# Altium schematic sheet LCL_FIlter.SchDoc
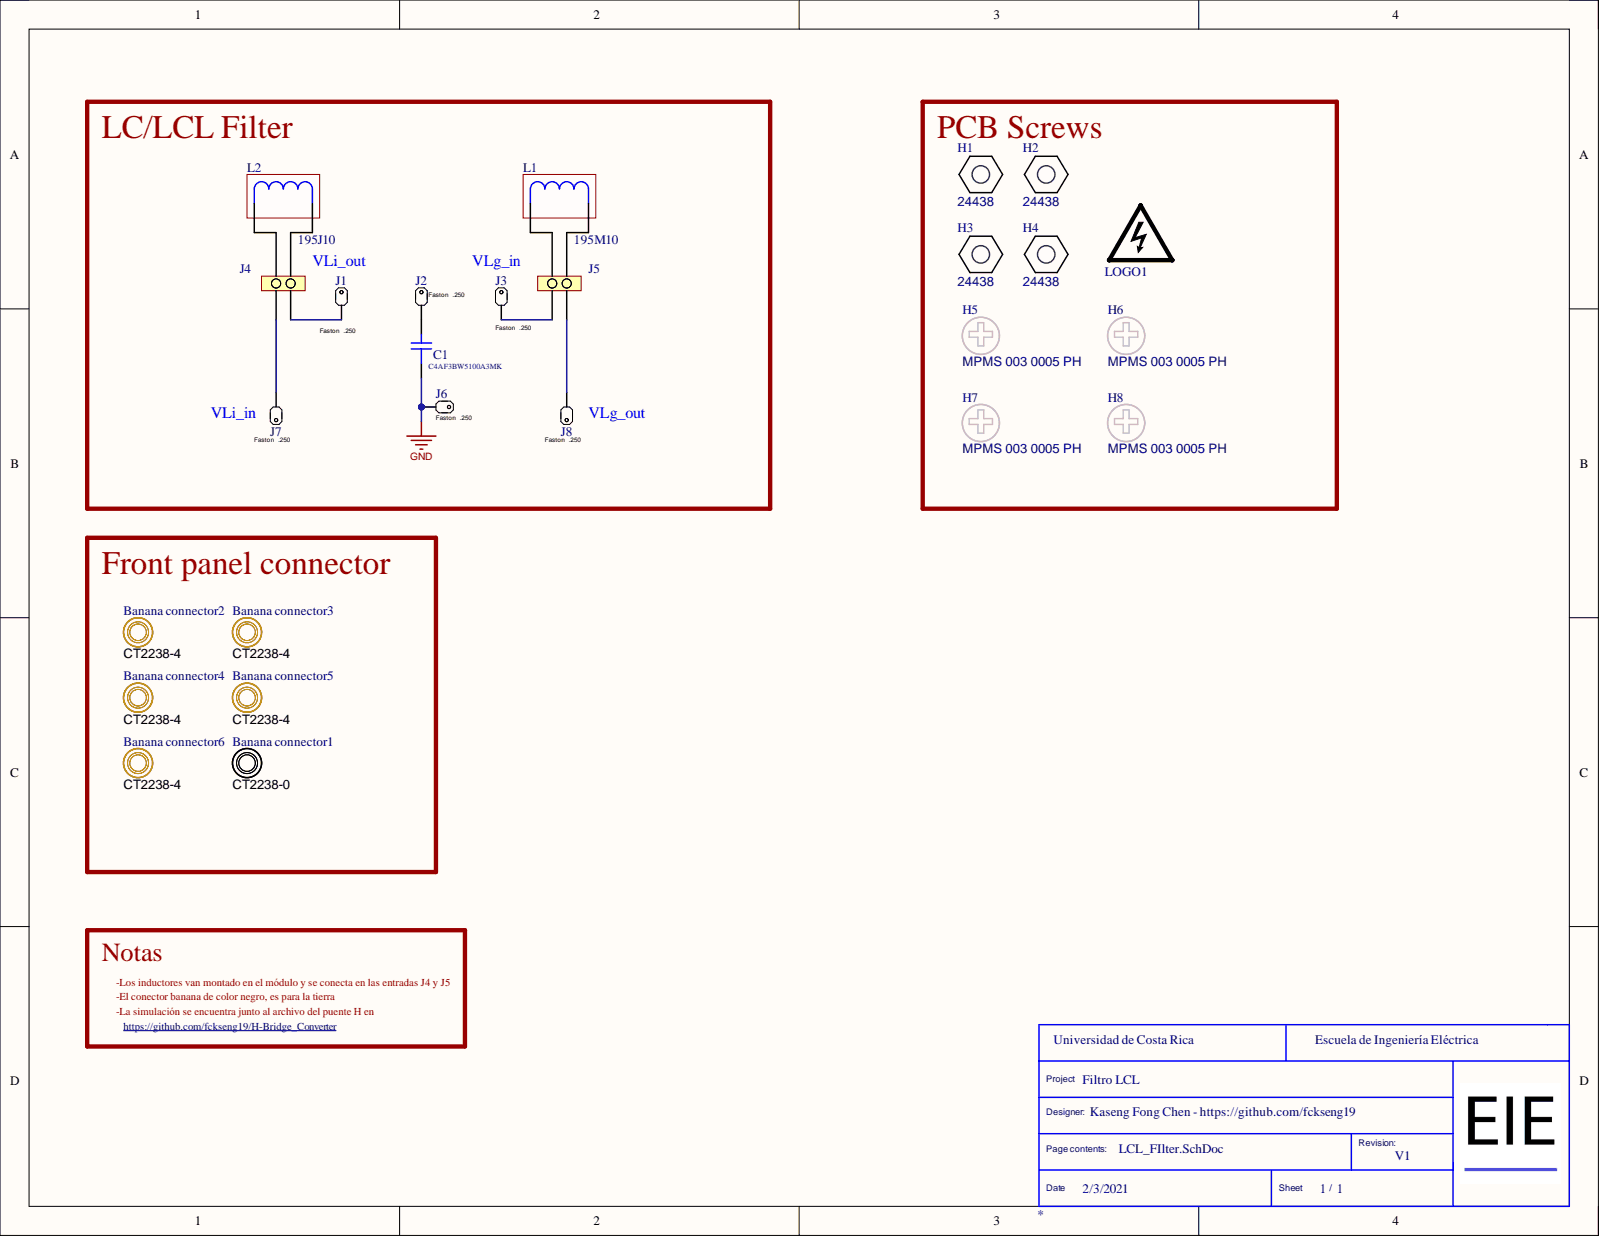
[diagram p0: LCL_FIlter.SchDoc]

## Parts
Banana_connector1  CT2238-0  (mechanical)
Banana_connector2  CT2238-4  (mechanical)
Banana_connector3  CT2238-4  (mechanical)
Banana_connector4  CT2238-4  (mechanical)
Banana_connector5  CT2238-4  (mechanical)
Banana_connector6  CT2238-4  (mechanical)
C1  C4AF3BW5100A3MK  [C4AF Capacitors]
H1  24438  [Keystone24438]
H2  24438  [Keystone24438]
H3  24438  [Keystone24438]
H4  24438  [Keystone24438]
H5  MPMS 003 0005 PH  [BuildingFastener_MPMS_003_0005_PH]
H6  MPMS 003 0005 PH  [BuildingFastener_MPMS_003_0005_PH]
H7  MPMS 003 0005 PH  [BuildingFastener_MPMS_003_0005_PH]
H8  MPMS 003 0005 PH  [BuildingFastener_MPMS_003_0005_PH]
J1  Faston  .250  [TE_62409-1]
J2  Faston  .250  [TE_62409-1]
J3  Faston  .250  [TE_62409-1]
J4  2P Terminal,  12-20  AWG  [TE_8PCV-02-006]  (value not drawn)
J5  2P Terminal,  12-20  AWG  [TE_8PCV-02-006]  (value not drawn)
J6  Faston  .250  [TE_62409-1]
J7  Faston  .250  [TE_62409-1]
J8  Faston  .250  [TE_62409-1]
L1  195M10
L2  195J10  (mechanical)
LOGO1  DANGER HIGH VOLTAGE  [IEC_HighVoltage_Medium]  (value not drawn)  (no BOM)

## Nets
GND: C1.2 J6.1
NetC1_1: C1.1 J2.1
NetJ1_1: J1.1 J4.1
NetJ3_1: J3.1 J5.2
NetJ4_2: J4.2 J7.1
NetJ5_1: J5.1 J8.1

## Library data of the parts
Banana_connector1 = CT2238-0: Cable Assembly 4MM Safety Jack, Panel W/.25 Fast on Terminal Black; Manufacturer: Cal Test Electronics; Manufacturer Part Number: CT2238-0; Pricing 1: 1=2,00000 (USD); RoHS: No; Stock 1: 1448; Supplier 1: Digi-Key; Supplier Part Number 1: BKCT2238-0-ND; Library Name: kasengLib.IntLib
Banana_connector2-Banana_connector6 = CT2238-4: Cable Assembly 4MM Safety Jack, Panel W/.25 Fast on Terminal Yellow; Manufacturer: Cal Test Electronics; Manufacturer Part Number: CT2238-0; Pricing 1: 1=2,00000 (USD); RoHS: No; Stock 1: 1448; Supplier 1: Digi-Key; Supplier Part Number 1: BKCT2238-0-ND; Library Name: kasengLib.IntLib
C1 = C4AF3BW5100A3MK: Cap Film 10uF 800V PP 10% (57.5 X 30 X 45mm) Radial 52.5mm 105°C Bulk; Manufacturer: KEMET; Category: Film Capacitors; Component Kind: Standard; Manufacturer Part Number: C4AF3BW5100A3MK; Pricing 1: 1=9,21000, 10=8,18900, 50=7,16540, 100=6,55120, 500=5,73230 (USD); RoHS: Yes; RoHS Compliant: Yes; Stock 1: 162; Supplier 1: Digi-Key; Supplier Part Number 1: 399-17837-ND; Library Name: kasengLib.IntLib
H1-H4 = CMP-0003640-1: Standoff, Hex,25mm Length, M3, Aluminum; Manufacturer: Keystone; CompType: Hardware; Component Kind: Standard; ComponentLink1Description: Datasheet Link; ComponentLink1URL: http://www.keyelco.com/userAssets/file/M60-2p72.pdf; Key: 24438; LatestRevisionDate: 2017-03-09; MountType: TH; PackageReference: Standoff M3; PartNumber: 24438; RoHS: Y; Supplier 1: Digi-Key; Supplier 2: Mouser; Supplier Part Number 1: 36-24438-ND; Supplier Part Number 2: 534-24438; Library Name: kasengLib.IntLib; Publisher: Texas Instruments
H5-H8 = CMP-0003646-1: MACHINE SCREW PAN PHILLIPS M3 5mm; Manufacturer: B&F Fastener Supply; CompType: Hardware; ClassName: Screw; Component Kind: Standard; ComponentLink1Description: Datasheet Link; ComponentLink1URL: http://www.fasnetdirect.com/refguide/MetD7985a.pdf; Key: MPMS 003 0005 PH; LatestRevisionDate: 2017-03-09; MountType: N/A; PackageReference: Screw M3 Phillips head; PartNumber: MPMS 003 0005 PH; RoHS: Y; Supplier 1: Digi-Key; Supplier Part Number 1: H742-ND; Library Name: kasengLib.IntLib; Publisher: Texas Instruments
J1-J3 J6-J8 = 62409-1: Quick Disconnect Terminal M 15.8mm 7.5mm Tin Over Copper Automotive Bag; Manufacturer: AMP - TE CONNECTIVITY; Component Kind: Standard; ComponentLink1Description: http://www.farnell.com/datasheets/1650963.pdf?src-supplier=Farnell; ComponentLink1URL: http://www.farnell.com/datasheets/1650963.pdf?src-supplier=Farnell; ComponentLink2Description: http://www.farnell.com/datasheets/1650963.pdf?src-supplier=Element14; ComponentLink2URL: http://www.farnell.com/datasheets/1650963.pdf?src-supplier=Element14; ComponentLink3Description: http://www.farnell.com/datasheets/1650963.pdf?src-supplier=Newark; ComponentLink3URL: http://www.farnell.com/datasheets/1650963.pdf?src-supplier=Newark; ComponentLink4Description: https://www.te.com/commerce/DocumentDelivery/DDEController?Action=srchrtrv&DocNm=62409&DocType=Customer+Drawing&DocLang=English&src-supplier=Digi-Key; ComponentLink4URL: https://www.te.com/commerce/DocumentDelivery/DDEController?Action=srchrtrv&DocNm=62409&DocType=Customer+Drawing&DocLang=English&src-supplier=Digi-Key; Manufacturer Part Number: 62409-1; Pricing 1: 1=0,19000, 10=0,17800, 25=0,15200, 50=0,13320, 100=0,12360, 250=0,10936, 750=0,09035, 1500=0,08083, 8000=0,06895 (USD); RoHS: No; Stock 1: 8729; Supplier 1: Newark; Supplier Part Number 1: 26AC8417; Library Name: kasengLib.IntLib
J4 J5 = 8PCV-02-006: Barrier Terminal Blocks VERTICAL RAISED 2P; Manufacturer: TE Connectivity; Current Rating: 30A; Max Voltage Rating (AC): 600V; Mounting Ears: No (Without); Number of Circuits: 2; Number of Levels: 1; Pitch: 11.1mm; Thread Size: M4; Wire Gauge (Max): 18AWG; Wire Gauge (Min): 10AWG; Category: Terminal Blocks; Color: Black; Component Kind: Standard; ComponentLink1Description: https://datasheet.ciiva.com/30214/8pcv02006-30214903.pdf?src-supplier=Onlinecomponents.com; ComponentLink1URL: https://datasheet.ciiva.com/30214/8pcv02006-30214903.pdf?src-supplier=Onlinecomponents.com; ComponentLink2Description: https://datasheet.ciiva.com/11746/0900766b80fa362c-11746107.pdf?src-supplier=RSComponents; ComponentLink2URL: https://datasheet.ciiva.com/11746/0900766b80fa362c-11746107.pdf?src-supplier=RSComponents; ComponentLink3Description: https://datasheet.ciiva.com/5181/eng-cd-6-1437657-3-k4-5181165.pdf?src-supplier=Mouser; ComponentLink3URL: https://datasheet.ciiva.com/5181/eng-cd-6-1437657-3-k4-5181165.pdf?src-supplier=Mouser; Contact Material: Copper Alloy; Contact Plating: Tin; Depth: 0.77inch; ELV: Compliant; Flammability Rating: UL94 V-0; Height: 0.77inch; Housing Material: Thermoplastic; Insulation Material: Thermoplastic; Length: 24.07mm; Manufacturer Part Number: 8PCV-02-006; Material: Thermoplastic; Max Current Rating: 30A; Max Operating Temperature: 248°C; Min Operating Temperature: -40°C; Mount: Through Hole; Mounting Insert: No (Without); Number of Contacts: 2; Number of Positions: 2; Number of Rows: 1; Number of Wire Entries: 2; Orientation: Straight; Package Quantity: 270; Pricing 1: 1=1,84000, 10=1,29200, 25=1,20480, 50=1,09360, 100=1,08880, 250=0,91940, 500=0,87102, 1000=0,77424, 5000=0,62907 (USD); RoHS: Yes; RoHS Compliant: Yes; Series: #8, Buchanan; Stock 1: 4811; Supplier 1: Digi-Key; Supplier Part Number 1: A98460-ND; Terminal Material: Copper Alloy; Termination: Screw; Voltage: 600V; Voltage Rating: 600V; Width: 0.8inch; Wire/Cable Gauge: 10AWG; Library Name: kasengLib.IntLib
L1 = 195M10: Fixed Inductors CHOKE; Manufacturer: Hammond Manufacturing; Current Rating: 10A; DC Resistance (DCR): 13mR; Inductance: 20mH; Shielding: Unshielded; Tolerance: 15%; Case/Package: 120; Category: Fixed Inductors; Component Kind: Standard; ComponentLink1Description: http://www.mouser.com/ds/2/177/195-196-980958.pdf?src-supplier=Mouser; ComponentLink1URL: http://www.mouser.com/ds/2/177/195-196-980958.pdf?src-supplier=Mouser; ComponentLink2Description: https://www.alliedelec.com/m/d/8463d4499fe931fd0b51c89e75ad4eff.pdf?src-supplier=Allied+Electronics; ComponentLink2URL: https://www.alliedelec.com/m/d/8463d4499fe931fd0b51c89e75ad4eff.pdf?src-supplier=Allied+Electronics; ComponentLink3Description: https://www.hammfg.com/electronics/transformers/choke/195-196.pdf?src-supplier=Digi-Key; ComponentLink3URL: https://www.hammfg.com/electronics/transformers/choke/195-196.pdf?src-supplier=Digi-Key; Height: 3.75inch; Length: 4.5inch; Manufacturer Part Number: 195M10; Max DC Current: 10A; Mount: Chassis Mount; Package Quantity: 1; Packaging: Bulk; Pricing 1: 1=82,90000 (USD); RoHS: Yes; RoHS Compliant: Yes; Series: 195; Stock 1: 20; Supplier 1: Digi-Key; Supplier Part Number 1: HM2136-ND; Termination: Screw; Weight: 10.2lb; Width: 4.75inch; Library Name: kasengLib.IntLib
L2 = 195J10: Fixed Inductors CHOKE; Manufacturer: Hammond Manufacturing; Current Rating: 10A; DC Resistance (DCR): 70mR; Inductance: 10mH; Shielding: Unshielded; Tolerance: 15%; Case/Package: Nonstandard; Category: Fixed Inductors; ComponentLink1Description: https://www.alliedelec.com/m/d/8463d4499fe931fd0b51c89e75ad4eff.pdf?src-supplier=Allied+Electronics; ComponentLink1URL: https://www.alliedelec.com/m/d/8463d4499fe931fd0b51c89e75ad4eff.pdf?src-supplier=Allied+Electronics; ComponentLink2Description: http://www.mouser.com/ds/2/177/5c0034-44711.pdf?src-supplier=Mouser; ComponentLink2URL: http://www.mouser.com/ds/2/177/5c0034-44711.pdf?src-supplier=Mouser; ComponentLink3Description: https://www.hammfg.com/electronics/transformers/choke/195-196.pdf?src-supplier=Digi-Key; ComponentLink3URL: https://www.hammfg.com/electronics/transformers/choke/195-196.pdf?src-supplier=Digi-Key; Height: 3.13inch; Height - Seated (Max): 3.13inch; Lead Free: Lead Free; Length: 3.75inch; Manufacturer Part Number: 195J10; Max DC Current: 10A; Mount: Chassis Mount; Package Quantity: 1; Packaging: Bulk; Pricing 1: 1=68,56000, 10=65,13000 (USD); RoHS: Yes; RoHS Compliant: Yes; Series: 195; Stock 1: 6; Supplier 1: Digi-Key; Supplier Part Number 1: HM2135-ND; Termination: Screw; Weight: 6.5lb; Width: 4.35inch; Library Name: kasengLib.IntLib
LOGO1 = IEC_HighVoltage: IEC 61010-1 High Voltage Warning; SymbolCreator: a0412235; LatestRevisionDate: 2017-07-06; Lib Reference: IEC_HighVoltage; PartNumber: DANGER HIGH VOLTAGE; Published: 2009-03-03; SymbolVersion: 2011-08-22; Library Name: kasengLib.IntLib; Publisher: Texas Instruments
